# Altium schematic sheet STB_SensorBoard.SchDoc
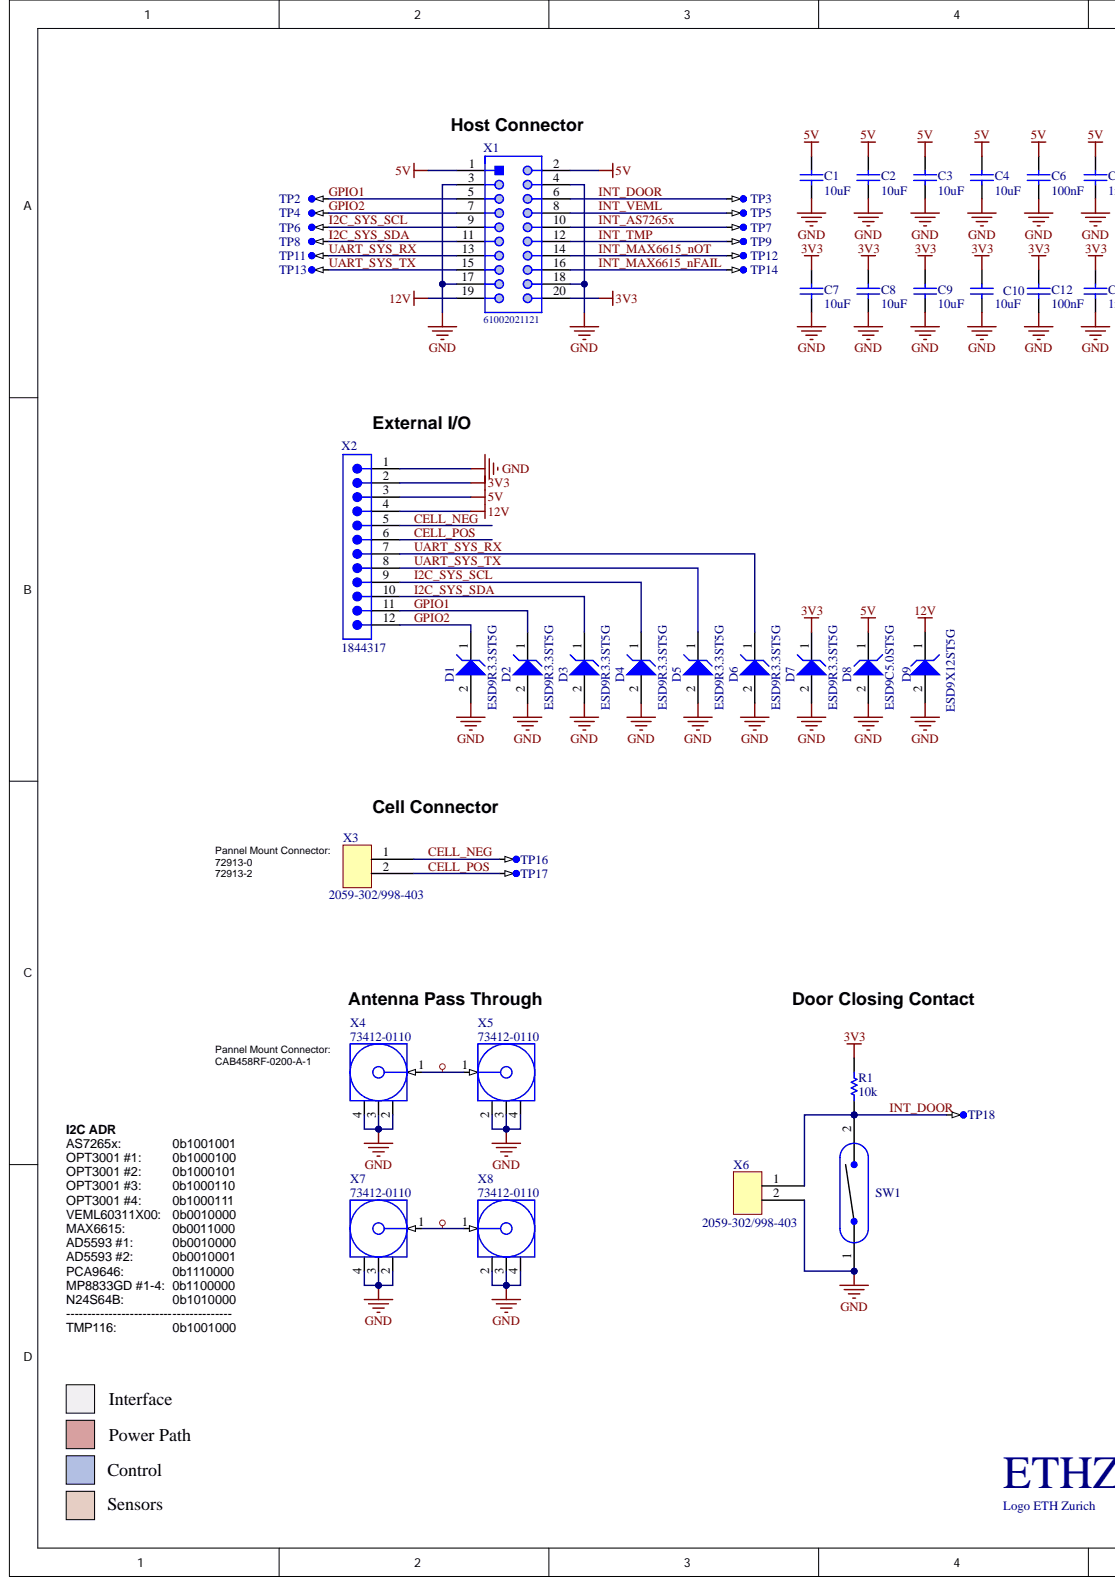
[diagram p0: STB_SensorBoard.SchDoc, left]
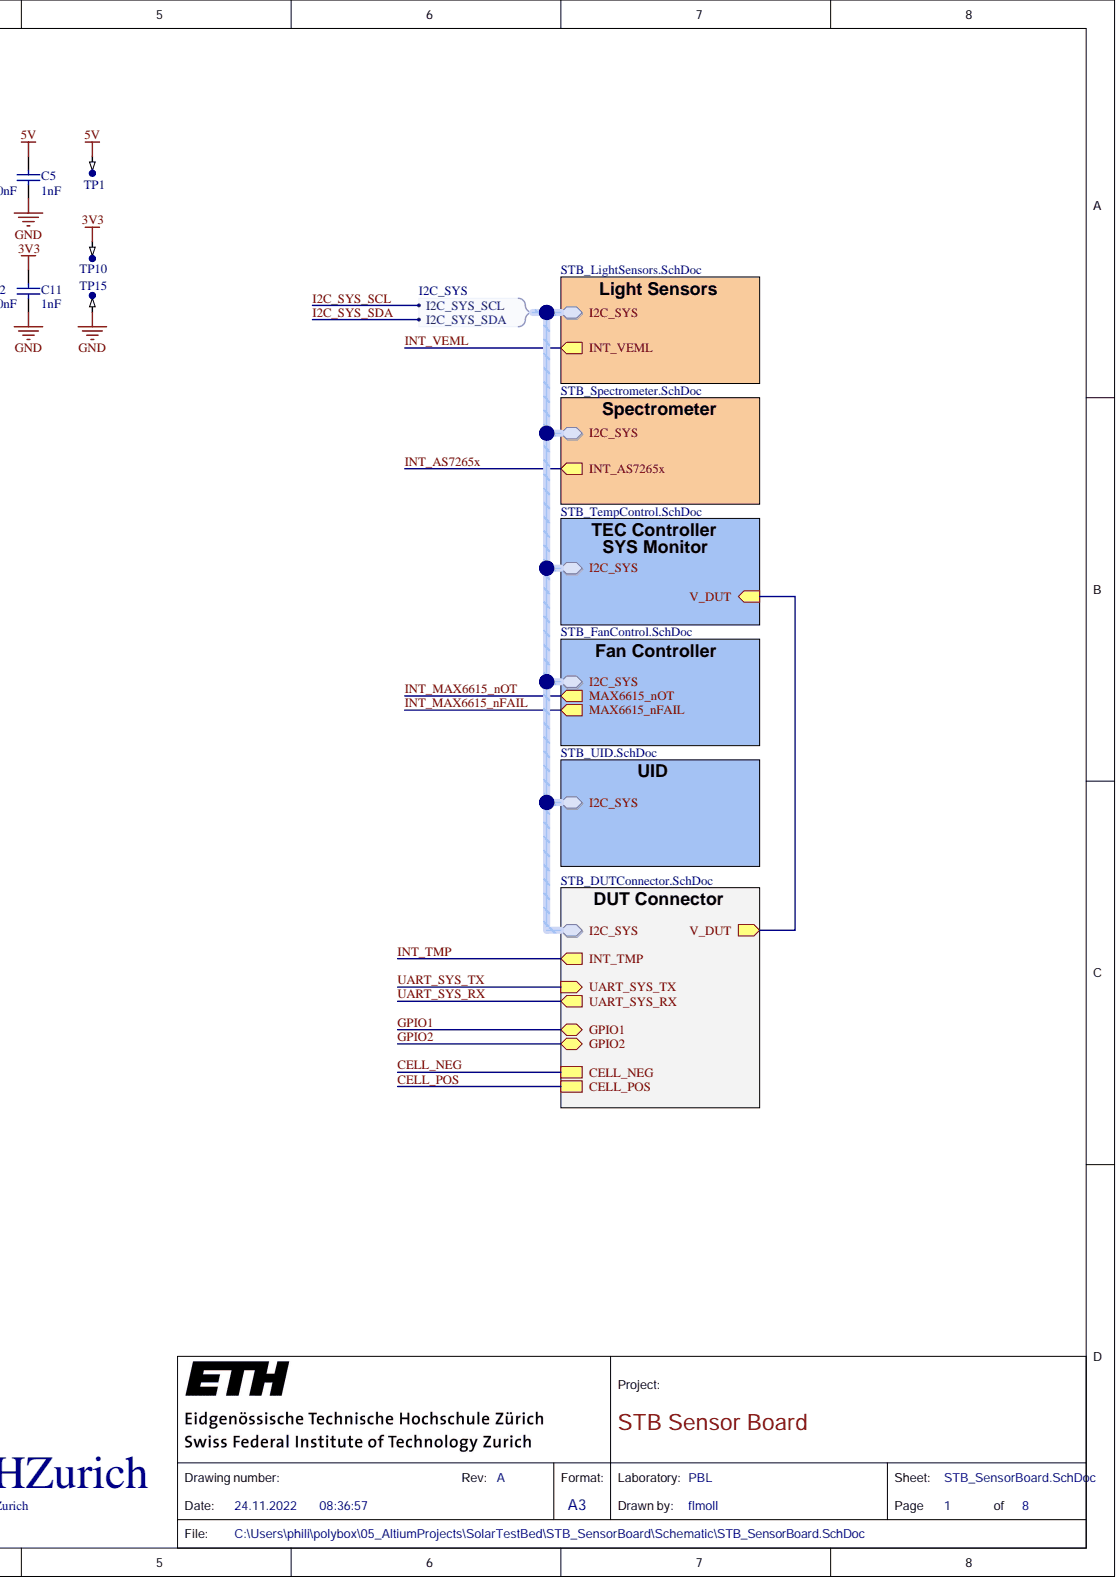
[diagram p1: STB_SensorBoard.SchDoc, right]

## Parts
A1  Logo ETH Zurich  [eth-zurich_5mm]  (no BOM)
C1  10uF  [CAPC0603(1608)100_L]
C2  10uF  [CAPC0603(1608)100_L]
C3  10uF  [CAPC0603(1608)100_L]
C4  10uF  [CAPC0603(1608)100_L]
C5  1nF  [CAPC1005X55X25ML5T10]
C6  100nF  [CAPC1050X55X23LL05T13]
C7  10uF  [CAPC0603(1608)100_L]
C8  10uF  [CAPC0603(1608)100_L]
C9  10uF  [CAPC0603(1608)100_L]
C10  10uF  [CAPC0603(1608)100_L]
C11  1nF  [CAPC1005X55X25ML5T10]
C12  100nF  [CAPC1050X55X23LL05T13]
D1  ESD9R3.3ST5G  [ONSC-SOD-923-2-514AB-01_V]
D2  ESD9R3.3ST5G  [ONSC-SOD-923-2-514AB-01_V]
D3  ESD9R3.3ST5G  [ONSC-SOD-923-2-514AB-01_V]
D4  ESD9R3.3ST5G  [ONSC-SOD-923-2-514AB-01_V]
D5  ESD9R3.3ST5G  [ONSC-SOD-923-2-514AB-01_V]
D6  ESD9R3.3ST5G  [ONSC-SOD-923-2-514AB-01_V]
D7  ESD9R3.3ST5G  [ONSC-SOD-923-2-514AB-01_V]
D8  ESD9C5.0ST5G  Rep Pk Reverse Voltage-Max: 5V  [ONSC-SOD-923-2-514AB-01_V]
D9  ESD9X12ST5G  Rep Pk Reverse Voltage-Max: 12V  [ONSC-SOD-923-2-514AA-01_V]
R1  10k  [RESC1005X40X25LL05T05]
SW1  MK17-B-2  [SMEL-MK17-B-2_V]  (value not drawn)
TP1  testPoint_1mm  [TP-SM-C-100]  (value not drawn)  (no BOM)
TP2  testPoint_1mm  [TP-SM-C-100]  (value not drawn)  (no BOM)
TP3  testPoint_1mm  [TP-SM-C-100]  (value not drawn)  (no BOM)
TP4  testPoint_1mm  [TP-SM-C-100]  (value not drawn)  (no BOM)
TP5  testPoint_1mm  [TP-SM-C-100]  (value not drawn)  (no BOM)
TP6  testPoint_1mm  [TP-SM-C-100]  (value not drawn)  (no BOM)
TP7  testPoint_1mm  [TP-SM-C-100]  (value not drawn)  (no BOM)
TP8  testPoint_1mm  [TP-SM-C-100]  (value not drawn)  (no BOM)
TP9  testPoint_1mm  [TP-SM-C-100]  (value not drawn)  (no BOM)
TP10  testPoint_1mm  [TP-SM-C-100]  (value not drawn)  (no BOM)
TP11  testPoint_1mm  [TP-SM-C-100]  (value not drawn)  (no BOM)
TP12  testPoint_1mm  [TP-SM-C-100]  (value not drawn)  (no BOM)
TP13  testPoint_1mm  [TP-SM-C-100]  (value not drawn)  (no BOM)
TP14  testPoint_1mm  [TP-SM-C-100]  (value not drawn)  (no BOM)
TP15  testPoint_1mm  [TP-SM-C-100]  (value not drawn)  (no BOM)
TP16  testPoint_1mm  [TP-SM-C-100]  (value not drawn)  (no BOM)
TP17  testPoint_1mm  [TP-SM-C-100]  (value not drawn)  (no BOM)
TP18  testPoint_1mm  [TP-SM-C-100]  (value not drawn)  (no BOM)
X1  61002021121  [61002021121]
X2  1844317  [1844317]
X3  2059-302/998-403  [2059-302/998-403]
X4  73412-0110  [MOLX-73412-0110_V]
X5  73412-0110  [MOLX-73412-0110_V]
X6  2059-302/998-403  [2059-302/998-403]
X7  73412-0110  [MOLX-73412-0110_V]
X8  73412-0110  [MOLX-73412-0110_V]

## Nets
3V3: C7.2 C8.2 C9.2 C10.2 C11.2 C12.2 D7.1 R1.2 TP10.TP X1.20 X2.2
5V: C1.2 C2.2 C3.2 C4.2 C5.2 C6.2 D8.1 TP1.TP X1.1 X1.2 X2.3
12V: D9.1 X1.19 X2.4
GND: C1.1 C2.1 C3.1 C4.1 C5.1 C6.1 C7.1 C8.1 C9.1 C10.1 C11.1 C12.1 D1.2 D2.2 D3.2 D4.2 D5.2 D6.2 D7.2 D8.2 D9.2 SW1.1 TP15.TP X1.3 X1.4 X1.17 X1.18 X2.1 X4.2 X4.3 X4.4 X5.2 X5.3 X5.4 X6.2 X7.2 X7.3 X7.4 X8.2 X8.3 X8.4
CELL_NEG: TP16.TP X2.5 X3.1
CELL_POS: TP17.TP X2.6 X3.2
GPIO1: D2.1 TP2.TP X1.5 X2.11
GPIO2: D1.1 TP4.TP X1.7 X2.12
I2C_SYS_SCL: D4.1 TP6.TP X1.9 X2.9
I2C_SYS_SDA: D3.1 TP8.TP X1.11 X2.10
INT_AS7265x: TP7.TP X1.10
INT_DOOR: R1.1 SW1.2 TP3.TP TP18.TP X1.6 X6.1
INT_MAX6615_nFAIL: TP14.TP X1.16
INT_MAX6615_nOT: TP12.TP X1.14
INT_TMP: TP9.TP X1.12
INT_VEML: TP5.TP X1.8
UART_SYS_RX: D6.1 TP11.TP X1.13 X2.7
UART_SYS_TX: D5.1 TP13.TP X1.15 X2.8
NetX4_1: X4.1 X5.1
NetX7_1: X7.1 X8.1
V_DUT:

## Library data of the parts
A1 = CMP-00038-1: Library Name: ETHZ Vault
C1-C4 C7-C10 = CMP-1035-02799-1: CAP 10uF 10V ±10% 0603 (1608 Metric) Thickness 1mm SMD; Manufacturer: Samsung; Manufacturer Part Number: CL10A106MP8NNNC; Case-EIA: 0603; Case-Metric: 1608; Dielectric: X5R; Tolerance: Â±10%; Capacitance: 10uF; Case Code (Imperial): 0603; Case Code (Metric): 1608; Case/Package: 0603; Category: Ceramic Capacitors; Component Kind: Standard; ComponentLink1Description: https://datasheet.ciiva.com/25793/cl10a106mp8nnnc-25793222.pdf?src-supplier=Digi-Key; ComponentLink1URL: https://datasheet.ciiva.com/25793/cl10a106mp8nnnc-25793222.pdf?src-supplier=Digi-Key; ComponentLink2Description: https://datasheet.ciiva.com/16200/cl10a106mp8nnnc-16200411.pdf?src-supplier=Future+Electronics; ComponentLink2URL: https://datasheet.ciiva.com/16200/cl10a106mp8nnnc-16200411.pdf?src-supplier=Future+Electronics; ComponentLink3Description: https://datasheet.ciiva.com/26946/getdatasheetpartid-357531-26946980.pdf?src-supplier=Verical; ComponentLink3URL: https://datasheet.ciiva.com/26946/getdatasheetpartid-357531-26946980.pdf?src-supplier=Verical; Contact Plating: Tin; Depth: 0.8mm; Height: 0.8mm; Lead Free: Lead Free; Length: 1.6mm; Library Name: Altium Content Vault; Max Operating Temperature: 85°C; Max Thickness: 1 mm; Min Operating Temperature: -55°C; Mount: Surface Mount; Package Quantity: 1; Packaging: Tape and Reel; Pricing 1: 1=0.26000, 10=0.17600, 50=0.10580, 100=0.08930, 500=0.06112, 1000=0.05407 (USD); Radiation Hardening: No; Rated Voltage: 10 V; RoHS: Yes; Stock 1: 643992; Supplier 1: Digi-Key; Supplier Part Number 1: 1276-1871-1-ND; Technology: SMT; Thickness: 0.035inch; Value: 10uF; Voltage Rating: 10V; Voltage Rating (DC): 10V; Width: 0.031inch
C5 C11 = CMP-1662-00017-1: Chip Capacitor, 1 nF, +/- 10%, 50 V, -55 to 125 degC, 0402 (1005 Metric), RoHS, Tape and Reel; Manufacturer: Murata; Manufacturer Part Number: GRM155R71H102KA01D; Capacitor Type: Ceramic; Case\/Package: 0402; Multilayer: Yes; Rated DC Voltage (URdc): 50V; Temperature Characteristics Code: X7R; Voltage Rating: 50 V; Case Code (Imperial): 0402; Case Code (Metric): 1005; Category: Ceramic Capacitors; Component Kind: Standard; ComponentLink1Description: Manufacturer URL; ComponentLink1URL: http://www.murata.com/; ComponentLink2Description: Datasheet; ComponentLink2URL: http://psearch.en.murata.com/capacitor/product/GRM155R71H102KA01%23.pdf; ComponentLink3Description: https://datasheet.ciiva.com:443/pdfs/VipMasterIC/IC/MURE/MURE-S-A0008911154/MURE-S-A0008911121-1.pdf?src-supplier=IHS+Markit; ComponentLink3URL: https://datasheet.ciiva.com:443/pdfs/VipMasterIC/IC/MURE/MURE-S-A0008911154/MURE-S-A0008911121-1.pdf?src-supplier=IHS+Markit; ComponentLink4Description: https://datasheet.ciiva.com/6072/1784401-6072451.pdf?src-supplier=Farnell; ComponentLink4URL: https://datasheet.ciiva.com/6072/1784401-6072451.pdf?src-supplier=Farnell; ComponentLink5Description: https://datasheet.ciiva.com/129/partnumbering-e-01-129755.pdf?src-supplier=Digi-Key; ComponentLink5URL: https://datasheet.ciiva.com/129/partnumbering-e-01-129755.pdf?src-supplier=Digi-Key; ComponentLink6Description: https://datasheet.ciiva.com/18101/getdatasheetpartid-37826-18101056.pdf?src-supplier=Verical; ComponentLink6URL: https://datasheet.ciiva.com/18101/getdatasheetpartid-37826-18101056.pdf?src-supplier=Verical; ComponentLink7Description: https://datasheet.ciiva.com/29199/454211-da-01-en-vs-kondensator-0402-29199736.pdf?src-supplier=Conrad; ComponentLink7URL: https://datasheet.ciiva.com/29199/454211-da-01-en-vs-kondensator-0402-29199736.pdf?src-supplier=Conrad; ComponentLink8Description: https://datasheet.ciiva.com/14116/grmseries-highdielectrictype-eng-14116845.pdf?src-supplier=Distrelec; ComponentLink8URL: https://datasheet.ciiva.com/14116/grmseries-highdielectrictype-eng-14116845.pdf?src-supplier=Distrelec; ComponentLink9Description: https://datasheet.ciiva.com/14116/grmseries-highdielectrictype-eng-14116906.pdf?src-supplier=Distrelec; ComponentLink9URL: https://datasheet.ciiva.com/14116/grmseries-highdielectrictype-eng-14116906.pdf?src-supplier=Distrelec; ComponentLink10Description: https://datasheet.ciiva.com/28659/608985-28659452.pdf?src-supplier=Element14; ComponentLink10URL: https://datasheet.ciiva.com/28659/608985-28659452.pdf?src-supplier=Element14; ComponentLink11Description: https://datasheet.ciiva.com/129/partnumbering-e-01-129754.pdf?src-supplier=Digi-Key; ComponentLink11URL: https://datasheet.ciiva.com/129/partnumbering-e-01-129754.pdf?src-supplier=Digi-Key; ComponentLink12Description: https://datasheet.ciiva.com/129/partnumbering-e-01-129753.pdf?src-supplier=Digi-Key; ComponentLink12URL: https://datasheet.ciiva.com/129/partnumbering-e-01-129753.pdf?src-supplier=Digi-Key; ComponentLink13Description: https://datasheet.ciiva.com/24217/c02e-24217773.pdf?src-supplier=Mouser; ComponentLink13URL: https://datasheet.ciiva.com/24217/c02e-24217773.pdf?src-supplier=Mouser; DatasheetVersion: 07/2016; Dielectric Material: Ceramic; Height: 0.5 mm; JESD-609 Code: e3; Length: 1 mm; Library Name: Altium Content Vault; Max Operating Temperature: 125 degC; Min Operating Temperature: -55 degC; Mount: Surface Mount; Mounting Technology: Surface Mount; Negative Tolerance: 10%; Number of Pins: 2; Package Quantity: 10000; Package Shape: Rectangular; Package Style: SMTMeter; PackageDescription: 2-Pin Surface Mount Device, Body 1 x 0.5 mm; PackageReference: 0402; Packaging: Tape and Reel; Pins: 2; Positive Tolerance: 10%; Pricing 1: 1=0.10000, 10=0.01800, 50=0.01000, 100=0.00810, 500=0.00578, 1000=0.00454, 2500=0.00412, 5000=0.00380 (USD); Radiation Hardening: No; REACH SVHC: No SVHC; Reach SVHC Compliant: Yes; RoHS: Yes; RoHS Compliant: Yes; RoHSCompliant: true; Size Code: 0402; Stock 1: 8959614; Supplier 1: Digi-Key; Supplier Part Number 1: 490-1303-6-ND; Terminal Finish: Nickel; Termination: Wraparound; Tolerance: 10%; Type (Capacitors): X7R; Value: 1 nF; Voltage Rating (DC): 50 V; Width: 0.5 mm
C6 C12 = CMP-2000-05439-1: Chip Capacitor, 100 nF, +/- 10%, 16 V, -55 to 125 degC, 0402 (1005 Metric), RoHS, Tape and Reel; Manufacturer: Samsung Electro-Mechanics; Manufacturer Part Number: CL05B104KO5NNNC; Capacitor Type: Ceramic; Dielectric: X7R; Multilayer: Yes; Rated DC Voltage (URdc): 16V; Case Size/Dimension: 0402; Category: Ceramic Capacitors; Component Kind: Standard; ComponentLink1Description: https://datasheet.ciiva.com:443/pdfs/VipMasterIC/IC/SEMS/SEMS-S-A0008384515/SEMS-S-A0008872921-1.pdf?src-supplier=IHS+Markit; ComponentLink1URL: https://datasheet.ciiva.com:443/pdfs/VipMasterIC/IC/SEMS/SEMS-S-A0008384515/SEMS-S-A0008872921-1.pdf?src-supplier=IHS+Markit; ComponentLink2Description: https://datasheet.ciiva.com/26920/getdatasheetpartid-359683-26920169.pdf?src-supplier=Verical; ComponentLink2URL: https://datasheet.ciiva.com/26920/getdatasheetpartid-359683-26920169.pdf?src-supplier=Verical; ComponentLink3Description: https://datasheet.ciiva.com/29198/458718-da-01-en-vs-kondensator-0402-29198423.pdf?src-supplier=Conrad; ComponentLink3URL: https://datasheet.ciiva.com/29198/458718-da-01-en-vs-kondensator-0402-29198423.pdf?src-supplier=Conrad; ComponentLink4Description: https://datasheet.ciiva.com/26309/mlcc-catalogdownload2013-eng-26309003.pdf?src-supplier=Distrelec; ComponentLink4URL: https://datasheet.ciiva.com/26309/mlcc-catalogdownload2013-eng-26309003.pdf?src-supplier=Distrelec; ComponentLink5Description: https://datasheet.ciiva.com/16208/cl05b104kq5nnnc-16208343.pdf?src-supplier=Future+Electronics; ComponentLink5URL: https://datasheet.ciiva.com/16208/cl05b104kq5nnnc-16208343.pdf?src-supplier=Future+Electronics; Datasheet URL: https://datasheet.ciiva.com/29198/458718-da-01-en-vs-kondensator-0402-29198423.pdf; Datasheet Version: Nov-10; Dielectric Material: Ceramic; Height: 0.55mm; JESD-609 Code: e3; Length: 1mm; Library Name: Altium Content Vault; Manufacturer URL: http://www.samsungsem.com/global/index.jsp; Max Operating Temperature: 125°C; Min Operating Temperature: -55°C; Mount: Surface Mount; Negative Tolerance: 10%; Number of Pins: 2; Package Shape: Rectangular; Package Style: SMTMeter; Packaging: Tape and Reel; Positive Tolerance: 10%; Pricing 1: 1=0.10000, 10=0.02400, 50=0.01300, 100=0.01050, 500=0.00752, 1000=0.00592, 2500=0.00538, 5000=0.00495 (USD); Rated Voltage: 16V; REACH SVHC: Yes; RoHS: Yes; RoHS Compliant: Yes; Size Code: 0402; Stock 1: 24854634; Supplier 1: Digi-Key; Supplier Part Number 1: 1276-1001-6-ND; Temperature Characteristics Code: X7R; Terminal Finish: Nickel; Termination: Wraparound; Tolerance (%): ±10%; Value: 100nF; Width: 0.5mm
D1-D7 = CMP-1063-00117-1: Transient Voltage Suppressor, ESD Protection Diode with Ultra-Low Leakage, 2-Pin SOD-923, Pb-Free, Tape and Reel; Manufacturer: On Semiconductor; Manufacturer Part Number: SZESD9R3.3ST5G; Breakdown Voltage-Nom: 4.8V; C Max (pF): 0.9; Clamping Voltage-Max: 7.8V; Diode Type: TRANS VOLTAGE SUPPRESSOR; Direction: Unidirectional; IR Max (uA): 0.001; Number of Lines: 1; Rep Pk Reverse Voltage-Max: 3.3V; V(BR) Min (V): 4.8; VRWM Max (V): 3.3; Category: ESD and Circuit Protection ICs; Component Kind: Standard; ComponentLink1Description: Manufacturer URL; ComponentLink1URL: http://www.onsemi.com/; ComponentLink2Description: Datasheet; ComponentLink2URL: http://www.onsemi.com/pub_link/Collateral/ESD9R3.3S-D.PDF; ComponentLink3Description: Package Specification; ComponentLink3URL: http://www.onsemi.com/pub_link/Collateral/514AB.PDF; ComponentLink4Description: https://datasheet.ciiva.com:443/pdfs/VipMasterIC/IC/ONSM/ONSM-S-A0003514262/ONSM-S-A0003583458-1.pdf?src-supplier=IHS+Markit; ComponentLink4URL: https://datasheet.ciiva.com:443/pdfs/VipMasterIC/IC/ONSM/ONSM-S-A0003514262/ONSM-S-A0003583458-1.pdf?src-supplier=IHS+Markit; ComponentLink5Description: https://datasheet.ciiva.com/24087/esd9r3-3s-d-241663-24087547.pdf?src-supplier=Mouser; ComponentLink5URL: https://datasheet.ciiva.com/24087/esd9r3-3s-d-241663-24087547.pdf?src-supplier=Mouser; DatasheetVersion: Rev. 1; JESD-609 Code: e3; Library Name: Altium Content Vault; Moisture Sensitivity Level: 1; Mounting Technology: Surface Mount; PackageDescription: 2-Pin SOD, Body 0.8 x 0.6 mm; PackageReference: SOD-923-2-514AB-01; PackageVersion: Rev. C, 03/2011; Packing: Tape and Reel; PartNumber: ESD9R3.3ST5G; Polarity: Unidirectional; Pricing 1: 1=0.61000, 10=0.52500, 100=0.39180, 500=0.30782, 1000=0.23786, 2000=0.21688 (USD); REACH SVHC: Yes; RoHS: Pb-Free; RoHS Compliant: Yes; Stock 1: 31698; Supplier 1: Digi-Key; Supplier Part Number 1: SZESD9R3.3ST5GOSDKR-ND; Surface Mount: Yes; Terminal Finish: Tin
D8 = CMP-1063-00110-1: Transient Voltage Suppressor, Micro-Packaged Diode for ESD Protection, 2-Pin SOD-923, Pb-Free, Tape and Reel; Manufacturer: On Semiconductor; C Max (pF): 6.2; Diode Element Material: SILICON; Diode Type: TRANS VOLTAGE SUPPRESSOR; Direction: Unidirectional; IR Max (uA): 0.5; Max Power Dissipation: 150mW; Min Breakdown Voltage: 11V; Number of Elements: 1; Package Body Material: Plastic; Qualification Status: Not Qualified; Reference Standard: AEC-Q101; Time @ Peak Reflow Temperature-Max (s): 40s; V(BR) Min (V): 11; VRWM Max (V): 5; Category: ESD and Circuit Protection ICs; Component Kind: Standard; ComponentLink1Description: Manufacturer URL; ComponentLink1URL: http://www.onsemi.com/; ComponentLink2Description: Datasheet; ComponentLink2URL: http://www.onsemi.cn/pub_link/Collateral/ESD9C3.3S-D.PDF; ComponentLink3Description: Package Specification; ComponentLink3URL: http://www.onsemi.com/pub_link/Collateral/514AB.PDF; ComponentLink4Description: https://datasheet.ciiva.com:443/pdfs/VipMasterIC/IC/ONSM/ONSM-S-A0003514262/ONSM-S-A0003579756-1.pdf?src-supplier=IHS+Markit; ComponentLink4URL: https://datasheet.ciiva.com:443/pdfs/VipMasterIC/IC/ONSM/ONSM-S-A0003514262/ONSM-S-A0003579756-1.pdf?src-supplier=IHS+Markit; ComponentLink5Description: https://datasheet.ciiva.com/1219/1748974-1219321.pdf?src-supplier=Newark; ComponentLink5URL: https://datasheet.ciiva.com/1219/1748974-1219321.pdf?src-supplier=Newark; ComponentLink6Description: https://datasheet.ciiva.com/4509/esd9c3-3s-d-241629-4509822.pdf?src-supplier=Mouser; ComponentLink6URL: https://datasheet.ciiva.com/4509/esd9c3-3s-d-241629-4509822.pdf?src-supplier=Mouser; ComponentLink7Description: https://datasheet.ciiva.com/15143/getdatasheetpartid-53763-15143125.pdf?src-supplier=Verical; ComponentLink7URL: https://datasheet.ciiva.com/15143/getdatasheetpartid-53763-15143125.pdf?src-supplier=Verical; ComponentLink8Description: https://datasheet.ciiva.com/339/esd9c3-3s-d-339778.pdf?src-supplier=Digi-Key; ComponentLink8URL: https://datasheet.ciiva.com/339/esd9c3-3s-d-339778.pdf?src-supplier=Digi-Key; ComponentLink9Description: https://datasheet.ciiva.com/11195/esd9c3-3s-d-11195341.pdf?src-supplier=Avnet; ComponentLink9URL: https://datasheet.ciiva.com/11195/esd9c3-3s-d-11195341.pdf?src-supplier=Avnet; ComponentLink10Description: https://datasheet.ciiva.com/339/esd9c3-3s-d-339779.pdf?src-supplier=Digi-Key; ComponentLink10URL: https://datasheet.ciiva.com/339/esd9c3-3s-d-339779.pdf?src-supplier=Digi-Key; ComponentLink11Description: https://datasheet.ciiva.com/339/esd9c3-3s-d-339780.pdf?src-supplier=Digi-Key; ComponentLink11URL: https://datasheet.ciiva.com/339/esd9c3-3s-d-339780.pdf?src-supplier=Digi-Key; DatasheetVersion: Rev. 3; JESD-30 Code: R-PDSO-F2; JESD-609 Code: e3; Library Name: Altium Content Vault; Manufacturer Part Number: ESD9C5.0ST5G; Max Operating Temperature: 150°C; Min Operating Temperature: -55°C; Moisture Sensitivity Level: 1; Mounting Technology: Surface Mount; Number of Lines: 1; Number of Pins: 2; Package Shape: Rectangular; Package Style: SMALL OUTLINEMeter; PackageDescription: 2-Pin SOD, Body 0.8 x 0.6 mm; PackageReference: SOD-923-2-514AB-01; PackageVersion: Rev. C, 03/2011; Packing: Tape and Reel; PartNumber: ESD9C5.0ST5G; Peak Reflow Temperature (Cel): 260°C; Polarity: Unidirectional; Pricing 1: 1=0.38000, 10=0.30900, 100=0.16370, 500=0.10772, 1000=0.07325, 2000=0.06607 (USD); REACH SVHC: Yes; RoHS: Pb-Free; RoHS Compliant: Yes; Stock 1: 345553; Supplier 1: Digi-Key; Supplier Part Number 1: ESD9C5.0ST5GOSDKR-ND; Surface Mount: Yes; Technology: AVALANCHE; Terminal Finish: Tin; Terminal Form: Flat; Terminal Position: DUAL
D9 = CMP-1063-00105-1: Transient Voltage Suppressor, Micro-Packaged Diode for ESD Protection, 2-Pin SOD-923, Pb-Free, Tape and Reel; Manufacturer: On Semiconductor; Clamping Voltage-Max: 23.7V; Diode Element Material: SILICON; Diode Type: TRANS VOLTAGE SUPPRESSOR; Direction: Unidirectional; IR Max (uA): 1; Max Power Dissipation: 150mW; Min Breakdown Voltage: 13.5V; Non-rep Peak Rev Power Dis-Max: 140W; Package Body Material: Plastic; PPK Max (W): 140; Qualification Status: Not Qualified; Time @ Peak Reflow Temperature-Max (s): 40s; V(BR) Min (V): 13.5; VRWM Max (V): 12; Category: ESD and Circuit Protection ICs; Component Kind: Standard; ComponentLink1Description: Manufacturer URL; ComponentLink1URL: http://www.onsemi.com/; ComponentLink2Description: Datasheet; ComponentLink2URL: http://www.onsemi.com/pub_link/Collateral/ESD9X3.3ST5G-D.PDF; ComponentLink3Description: Package Specification; ComponentLink3URL: http://www.onsemi.com/pub_link/Collateral/514AA.PDF; ComponentLink4Description: https://datasheet.ciiva.com:443/pdfs/VipMasterIC/IC/ONSM/ONSM-S-A0003579749/ONSM-S-A0003579749-1.pdf?src-supplier=IHS+Markit; ComponentLink4URL: https://datasheet.ciiva.com:443/pdfs/VipMasterIC/IC/ONSM/ONSM-S-A0003579749/ONSM-S-A0003579749-1.pdf?src-supplier=IHS+Markit; ComponentLink5Description: https://datasheet.ciiva.com/6577/esd9x3-3st5g-d-6577990.pdf?src-supplier=Digi-Key; ComponentLink5URL: https://datasheet.ciiva.com/6577/esd9x3-3st5g-d-6577990.pdf?src-supplier=Digi-Key; ComponentLink6Description: https://datasheet.ciiva.com/16393/esd9x3-3st5g-d-16393983.pdf?src-supplier=Future+Electronics; ComponentLink6URL: https://datasheet.ciiva.com/16393/esd9x3-3st5g-d-16393983.pdf?src-supplier=Future+Electronics; ComponentLink7Description: https://datasheet.ciiva.com/353/esd9x3-3st5g-d-353784.pdf?src-supplier=Digi-Key; ComponentLink7URL: https://datasheet.ciiva.com/353/esd9x3-3st5g-d-353784.pdf?src-supplier=Digi-Key; ComponentLink8Description: https://datasheet.ciiva.com/353/esd9x3-3st5g-d-353785.pdf?src-supplier=Digi-Key; ComponentLink8URL: https://datasheet.ciiva.com/353/esd9x3-3st5g-d-353785.pdf?src-supplier=Digi-Key; DatasheetVersion: Rev. 7; JESD-30 Code: R-PDSO-F2; JESD-609 Code: e3; Library Name: Altium Content Vault; Manufacturer Part Number: ESD9X12ST5G; Max Operating Temperature: 150°C; Min Operating Temperature: -55°C; Moisture Sensitivity Level: 1; Mounting Technology: Surface Mount; Number of Elements: 1; Number of Lines: 1; Number of Pins: 2; Package Shape: Rectangular; Package Style: SMALL OUTLINEMeter; PackageDescription: 2-Pin SOD, Body 0.8 x 0.6 mm; PackageReference: SOD-923-2-514AA-01; PackageVersion: Rev. E, 03/2011; Packing: Tape and Reel; PartNumber: ESD9X12ST5G; Peak Reflow Temperature (Cel): 260°C; Polarity: Unidirectional; Pricing 2: 1=0.30000, 10=0.24400, 100=0.12930, 500=0.08506, 1000=0.05784, 2000=0.05218 (USD); REACH SVHC: Yes; RoHS: Pb-Free; RoHS Compliant: Yes; Stock 2: 71759; Supplier 1: Digi-Key; Supplier 2: Digi-Key; Supplier Part Number 2: ESD9X12ST5GOSDKR-ND; Surface Mount: Yes; Technology: AVALANCHE; Terminal Finish: Tin; Terminal Form: Flat; Terminal Position: DUAL
R1 = CMP-2000-07588-1: Chip Resistor, 10 KOhm, +/- 1%, 0.1 W, -55 to 155 degC, 0402 (1005 Metric), RoHS, Tape and Reel; Manufacturer: Panasonic; Manufacturer Part Number: ERJ-2RKF1002X; Case\/Package: 0402; Max Power Dissipation: 100mW; Package Height: 0.35mm; Package Length: 1mm; Package Width: 0.5mm; Rated Temperature: 70°C; Reference Standard: AEC-Q200; Temperature Coefficient: 100ppm\/degreesC; Value: 10 kOhm; Voltage Rating: 50V; Case Code (Imperial): 0402; Case Code (Metric): 1005; Category: Chip SMD Resistors; Component Kind: Standard; ComponentLink1Description: https://datasheet.ciiva.com:443/pdfs/VipMasterIC/IC/PANA/PANA-S-A0009889626/PANA-S-A0009889626-1.pdf?src-supplier=IHS+Markit; ComponentLink1URL: https://datasheet.ciiva.com:443/pdfs/VipMasterIC/IC/PANA/PANA-S-A0009889626/PANA-S-A0009889626-1.pdf?src-supplier=IHS+Markit; ComponentLink2Description: https://datasheet.ciiva.com/11821/0900766b80f80784-11821171.pdf?src-supplier=RSComponents; ComponentLink2URL: https://datasheet.ciiva.com/11821/0900766b80f80784-11821171.pdf?src-supplier=RSComponents; ComponentLink3Description: https://datasheet.ciiva.com/27055/1987020-27055643.pdf?src-supplier=Jameco; ComponentLink3URL: https://datasheet.ciiva.com/27055/1987020-27055643.pdf?src-supplier=Jameco; ComponentLink4Description: https://datasheet.ciiva.com/43467/1678782-43467402.pdf?src-supplier=Farnell; ComponentLink4URL: https://datasheet.ciiva.com/43467/1678782-43467402.pdf?src-supplier=Farnell; ComponentLink5Description: https://datasheet.ciiva.com/28514/1678782-28514185.pdf?src-supplier=Element14; ComponentLink5URL: https://datasheet.ciiva.com/28514/1678782-28514185.pdf?src-supplier=Element14; ComponentLink6Description: https://datasheet.ciiva.com/24023/aoa0000ce2-24023017.pdf?src-supplier=Mouser; ComponentLink6URL: https://datasheet.ciiva.com/24023/aoa0000ce2-24023017.pdf?src-supplier=Mouser; ComponentLink7Description: https://datasheet.ciiva.com/16782/70260748-16782590.pdf?src-supplier=Allied+Electronics; ComponentLink7URL: https://datasheet.ciiva.com/16782/70260748-16782590.pdf?src-supplier=Allied+Electronics; ComponentLink8Description: https://datasheet.ciiva.com/16786/70260748-16786734.pdf?src-supplier=Allied+Electronics; ComponentLink8URL: https://datasheet.ciiva.com/16786/70260748-16786734.pdf?src-supplier=Allied+Electronics; ComponentLink9Description: https://datasheet.ciiva.com/9194/aoa0000ce2-9194142.pdf?src-supplier=Avnet; ComponentLink9URL: https://datasheet.ciiva.com/9194/aoa0000ce2-9194142.pdf?src-supplier=Avnet; ComponentLink10Description: https://datasheet.ciiva.com/16199/aoa0000ce2-16199478.pdf?src-supplier=Future+Electronics; ComponentLink10URL: https://datasheet.ciiva.com/16199/aoa0000ce2-16199478.pdf?src-supplier=Future+Electronics; ComponentLink11Description: https://datasheet.ciiva.com/48531/aoa0000ce2-48531069.pdf?src-supplier=Avnet; ComponentLink11URL: https://datasheet.ciiva.com/48531/aoa0000ce2-48531069.pdf?src-supplier=Avnet; ComponentLink12Description: https://datasheet.ciiva.com/30201/aoa0000ce2-30201022.pdf?src-supplier=Onlinecomponents.com; ComponentLink12URL: https://datasheet.ciiva.com/30201/aoa0000ce2-30201022.pdf?src-supplier=Onlinecomponents.com; Composition: ThickFilm; Construction: Rectangular; Contact Plating: Tin; Datasheet URL: https://industrial.panasonic.com/cdbs/www-data/pdf/RDA0000/AOA0000C304.pdf; Datasheet Version: 02/2017; Depth: 0.5mm; Height: 0.35mm; JESD-609 Code: e3; Lead Free: LeadFree; Length: 1mm; Library Name: Altium Content Vault; Manufacturer URL: http://www.panasonic.com/; Max Operating Temperature: 155degC; Military Standard: Not; Min Operating Temperature: -55degC; Mount: SurfaceMount; Mounting Technology: SurfaceMount; Number of Pins: 2; Number of Terminations: 2; Package Description: 2-Pin Surface Mount Device, Body 1 x 0.5 mm; Package Quantity: 1; Package Reference: 402; Package Shape: Rectangular; Package Style: SMTMeter; Packaging: TapeandReel; Power Rating: 100mW; Pricing 1: 1=0.10000, 10=0.03300, 100=0.01330, 1000=0.00599, 2500=0.00520, 5000=0.00429 (USD); Radiation Hardening: No; Rated Power Dissipation (P): 100mW; REACH SVHC: NoSVHC; Resistor Type: FIXED RESISTOR; RoHS: Yes; RoHS Compliant: Yes; RoHSCompliant: True; Size Code: 0402; Stock 1: 108; Supplier 1: Digi-Key; Supplier Part Number 1: P10.0KLDKR-ND; Technology: METAL GLAZE/THICK FILM; Terminal Finish: Nickel; Termination: SMD/SMT; Tolerance: 1%; Voltage Rating (DC): 50V; Width: 0.02inch; Working Voltage: 50V
SW1 = CMP-2000-06713-1: Molded Body Reed Switch, 100 V, 10 W, -40 to 130 degC, 2-Pin SMD, RoHS, Tape and Reel; Manufacturer: Standex Meder; Body Breadth: 2.1inch; Body Height: 2.1mm; Body Length or Diameter: 8.5mm; Package Shape/Style: Rectangular; Response Time: 100us; Sensors/Transducers Type: PROXIMITY SENSOR,PHOTOELECTRIC; Category: Signal Relays; Component Kind: Standard; ComponentLink1Description: https://datasheet.ciiva.com:443/pdfs/VipMasterIC/IC/STME/STME-S-A0010126788/STME-S-A0010126848-1.pdf?src-supplier=IHS+Markit; ComponentLink1URL: https://datasheet.ciiva.com:443/pdfs/VipMasterIC/IC/STME/STME-S-A0010126788/STME-S-A0010126848-1.pdf?src-supplier=IHS+Markit; ComponentLink2Description: https://datasheet.ciiva.com/2818/9171800022e-2818053.pdf?src-supplier=Digi-Key; ComponentLink2URL: https://datasheet.ciiva.com/2818/9171800022e-2818053.pdf?src-supplier=Digi-Key; ComponentLink3Description: https://datasheet.ciiva.com/4626/9171800022e-11078-4626565.pdf?src-supplier=Mouser; ComponentLink3URL: https://datasheet.ciiva.com/4626/9171800022e-11078-4626565.pdf?src-supplier=Mouser; ComponentLink4Description: https://datasheet.ciiva.com/1320/96345-1320425.pdf?src-supplier=Element14; ComponentLink4URL: https://datasheet.ciiva.com/1320/96345-1320425.pdf?src-supplier=Element14; ComponentLink5Description: https://datasheet.ciiva.com/15099/getdatasheetpartid-639745-15099041.pdf?src-supplier=Verical; ComponentLink5URL: https://datasheet.ciiva.com/15099/getdatasheetpartid-639745-15099041.pdf?src-supplier=Verical; ComponentLink6Description: https://datasheet.ciiva.com/30182/9171800022e-30182855.pdf?src-supplier=Onlinecomponents.com; ComponentLink6URL: https://datasheet.ciiva.com/30182/9171800022e-30182855.pdf?src-supplier=Onlinecomponents.com; ComponentLink7Description: https://datasheet.ciiva.com/15697/23-en-1-sensormk17e-15697662.pdf?src-supplier=Onlinecomponents.com; ComponentLink7URL: https://datasheet.ciiva.com/15697/23-en-1-sensormk17e-15697662.pdf?src-supplier=Onlinecomponents.com; Datasheet URL: http://www.masterelectronics.com/productFiles/mf-MDR/9171800022e.pdf; Datasheet Version: Rev. 17, 12/2014; Housing Material: Resin; Library Name: Altium Content Vault; Manufacturer Part Number: MK17-B-2; Manufacturer URL: https://standexelectronics.com; Max Operating Temperature: 130°C; Min Operating Temperature: -40°C; Mount: Surface Mount; Mounting Technology: SurfaceMount; Package Description: 2-Pin Surface Mount Device, Body 8.5 x 2.1 mm; Package Reference: MK17-B-2; Pricing 1: 2000=1.64933, 4000=1.49834, 6000=1.43750 (USD); REACH SVHC: No SVHC; RoHS: Yes; RoHS Compliant: Yes; Stock 1: 6000; Supplier 1: Digi-Key; Supplier Part Number 1: 374-1021-2-ND; Termination: Solder
TP1-TP18 = testPoint_1mm: testPoint 1mm; Library Name: STB_Schlib.SchLib
X1 = CMP-1502-01036-1: SMT Vertical Pin Header WR-PHD, Pitch 2.54 mm, Dual Row, 20 pins; Manufacturer: Wurth Elektronik; Manufacturer Series: 6100; Body Breadth: 0.2inch; Body Depth: 0.142inch; Body Length: 1inch; Body/Shell Style: RECEPTACLE; Connector Type: BOARD CONNECTOR; Contact Pattern: RECTANGULAR; Contact Resistance: 20mOhm; Contact Style: SQ PIN-SKT; Dielectric Withstanding Voltage (AC): 500V; DIN Conformance: No; Gender: Male; Insulation Resistance: 1GR; Mating Contact Pitch: 0.1inch; Mounting Option 1: LOCKING; Number Of Connectors: 1; Number of Contacts: 20; Number Of PCB Rows: 2; Option: General Purpose; PCB Contact Row Spacing: 5.4102mm; Pitch: 2.54mm; Rated Current: 3A; Reference Standard: UL; Reliability: COMMERCIAL; Withstanding Voltage: 500VAC; Working Voltage: 250VAC; Category: Connectors; Component Kind: Standard; ComponentLink1Description: https://datasheet.ciiva.com:443/pdfs/VipMasterIC/IC/MIDC/MIDCS04347/MIDCS04347-1.pdf?src-supplier=IHS+Markit; ComponentLink1URL: https://datasheet.ciiva.com:443/pdfs/VipMasterIC/IC/MIDC/MIDCS04347/MIDCS04347-1.pdf?src-supplier=IHS+Markit; ComponentLink2Description: https://katalog.we-online.de/em/datasheet/6100xx21121.pdf?src-supplier=Digi-Key; ComponentLink2URL: https://katalog.we-online.de/em/datasheet/6100xx21121.pdf?src-supplier=Digi-Key; ComponentLink3Description: http://www.farnell.com/cad/1737271.pdf?src-supplier=Element14; ComponentLink3URL: http://www.farnell.com/cad/1737271.pdf?src-supplier=Element14; Contact Finish - Mating: GOLD; Contact Finish - Termination: Gold (Au) - with Nickel (Ni) barrier; Contact Gender: Male; Contact Material: Copper Alloy; Datasheet URL: https://katalog.we-online.de/em/datasheet/6100xx21121.pdf; Filter Feature: No; Flammability Rating: UL94 V-0; IEC Conformance: No; Insulation Color: Black; Insulation Material: Polyamide; JESD-609 Code: e4; Library Name: Altium Content Vault; Manufacturer Part Number: 61002021121; Manufacturer URL: http://www.we-online.de; Mating Contact Row Spacing: 0.1inch; Max Operating Temperature: 125°C; MIL Conformance: No; Min Operating Temperature: -40°C; Mixed Contacts: No; Mount: Board; Mounting Style: STRAIGHT; Mounting Technology: SMT; Number of Rows Loaded: 2; Operating Temperature: -40to125degC; Orientation: Vertical; Package Reference: 61002021121; Packaging: Tube; Part Number: 61002021121; PCB Contact Pattern: RECTANGULAR; Pin Number: 20; Pricing 1: 1=3.72000, 10=3.15900, 24=2.63208, 48=2.19292, 96=1.99302, 192=1.81302 (USD); Rated Current (Signal): 3A; REACH SVHC: Yes; RoHS: Yes; RoHS Compliant: Yes; Row Number: Dual; Stock 1: 578; Supplier 1: Digi-Key; Supplier Part Number 1: 732-5468-5-ND; Terminal Pitch: 2.54mm; Termination: SMD/SMT; Publisher: WurthElektronik
X2 = CMP-00203-1: Manufacturer: Phoenix Contact; Current - IEC: 12A; Current - UL: 8A; Header Orientation: 90°, Right Angle; Number of Levels: 1; Number of Positions: 12; Voltage - IEC: 250V; Voltage - UL: 300V; Color: Green; Component Kind: Standard; ComponentLink1Description: 1844317.pdf; ComponentLink1URL: http://media.digikey.com/pdf/Data%20Sheets/Phoenix%20Contact%20PDFs/1844317.pdf; Contact Mating Finish: Tin; Features: -; Library Name: ETHZ Vault; Manufacturer Part Number: 1844317; Mounting Type: Through Hole; Operating Temperature: -; Packaging: Bulk; Part Status: Active; Plug Wire Entry: -; Positions Per Level: 12; Supplier: Digi-Key; Supplier Part Number: 277-5902-ND; Termination Style: Solder; Type: Header, Male Pins, Shrouded (4 Side); Wire Gauge or Range - AWG: -; Wire Gauge or Range - mm²: -
X3 X6 = 2059-302/998-403: Barrier Strip Terminal Block, 3A, 0.34mm2, 1 Row(s), 1 Deck(s); Manufacturer: WAGO; Fastening Method: PUSH-IN; Number of Decks: 1; Number of Ways: 2; Safety Approval: UL; Voltage Rating: 250V; Wire/Cable Gauge: 22AWG; Category: Connectors; Component Kind: Standard; ComponentLink1Description: https://datasheet.ciiva.com:443/pdfs/VipMasterIC/IC/WAGO/WAGO-S-A0007107760/WAGO-S-A0007107948-1.pdf?src-supplier=IHS+Markit; ComponentLink1URL: https://datasheet.ciiva.com:443/pdfs/VipMasterIC/IC/WAGO/WAGO-S-A0007107760/WAGO-S-A0007107948-1.pdf?src-supplier=IHS+Markit; ComponentLink2Description: https://datasheet.ciiva.com/41441/001208172-da-01-de-smd-leiterplattenklemme-2059-302-998-404-41441808.pdf?src-supplier=Conrad; ComponentLink2URL: https://datasheet.ciiva.com/41441/001208172-da-01-de-smd-leiterplattenklemme-2059-302-998-404-41441808.pdf?src-supplier=Conrad; ComponentLink3Description: https://datasheet.ciiva.com/46435/1860449-46435420.pdf?src-supplier=Element14; ComponentLink3URL: https://datasheet.ciiva.com/46435/1860449-46435420.pdf?src-supplier=Element14; Current Rating: 3A; Manufacturer Part Number: 2059-302/998-403; Mount: Board; Number of Rows: 1; Pricing 1: 1=1.25000, 10=0.87800, 25=0.81880, 50=0.74320, 100=0.73990, 250=0.62484, 500=0.59194 (USD); REACH SVHC: Yes; RoHS: Yes; RoHS Compliant: Yes; Stock 1: 17368; Supplier 1: Digi-Key; Supplier Part Number 1: 2946-2059-302/998-403CT-ND; Terminal and Terminal Block Type: BARRIER STRIP TERMINAL BLOCK; Wire Cross Section: 0.34mm2; Library Name: STB_Schlib.SchLib
X4 X5 X7 X8 = CMP-1676-00003-1: MCRF, PCB Vertical Jack Receptacle, 50 Ohm, 4-Pin SMD, RoHS, Tape and Reel; Manufacturer: Molex; Body Material: Polymer,Liquid Crystal Polymer; Body Plating: Gold; Connector Type: Coaxial; Frequency: 6 Hz; Gender: Female; Impedance: 50 Ohm; Insulation Resistance: 500MR; Mating Cycles: 30; Max Frequency: 6GHz; Polarity: Standard; Voltage Rating: 60 V; Category: RF / Coaxial Connectors; Component Kind: Standard; ComponentLink1Description: Manufacturer URL; ComponentLink1URL: http://www.molex.com/; ComponentLink2Description: Datasheet; ComponentLink2URL: http://www.molex.com/webdocs/datasheets/pdf/en-us/0734120110_RF_COAX_CONNECTORS.pdf; ComponentLink3Description: Package Specification; ComponentLink3URL: http://www.molex.com/pdm_docs/sd/734120110_sd.pdf; ComponentLink4Description: https://datasheet.ciiva.com/30219/250360734120110-rf-coax-nectors-30219809.pdf?src-supplier=Onlinecomponents.com; ComponentLink4URL: https://datasheet.ciiva.com/30219/250360734120110-rf-coax-nectors-30219809.pdf?src-supplier=Onlinecomponents.com; ComponentLink5Description: https://datasheet.ciiva.com/16653/0734120110-rf-coax-connectors-16653186.pdf?src-supplier=Avnet+Europe; ComponentLink5URL: https://datasheet.ciiva.com/16653/0734120110-rf-coax-connectors-16653186.pdf?src-supplier=Avnet+Europe; ComponentLink6Description: https://datasheet.ciiva.com/11762/0900766b80dfdeb4-11762356.pdf?src-supplier=RSComponents; ComponentLink6URL: https://datasheet.ciiva.com/11762/0900766b80dfdeb4-11762356.pdf?src-supplier=RSComponents; ComponentLink7Description: https://datasheet.ciiva.com/22773/1841262-22773140.pdf?src-supplier=Farnell; ComponentLink7URL: https://datasheet.ciiva.com/22773/1841262-22773140.pdf?src-supplier=Farnell; ComponentLink8Description: https://datasheet.ciiva.com/4600/0734120110-rf-coax-connectors-173239-4600647.pdf?src-supplier=Mouser; ComponentLink8URL: https://datasheet.ciiva.com/4600/0734120110-rf-coax-connectors-173239-4600647.pdf?src-supplier=Mouser; ComponentLink9Description: https://datasheet.ciiva.com/16081/1387750734120110-rf-coax-nectors-16081145.pdf?src-supplier=Onlinecomponents.com; ComponentLink9URL: https://datasheet.ciiva.com/16081/1387750734120110-rf-coax-nectors-16081145.pdf?src-supplier=Onlinecomponents.com; ComponentLink10Description: https://datasheet.ciiva.com/46384/1808577-46384459.pdf?src-supplier=Element14; ComponentLink10URL: https://datasheet.ciiva.com/46384/1808577-46384459.pdf?src-supplier=Element14; ComponentLink11Description: https://datasheet.ciiva.com/9419/0734120110-rf-coax-connectors-9419357.pdf?src-supplier=Avnet; ComponentLink11URL: https://datasheet.ciiva.com/9419/0734120110-rf-coax-connectors-9419357.pdf?src-supplier=Avnet; Contact Material: Bronze; Contact Plating: Gold; DatasheetVersion: 04/2016; Halogen Free: Low Halogen; Height: 1.25 mm; Lead Free: Lead Free; Length: 3 mm; Library Name: Altium Content Vault; Manufacturer Part Number: 73412-0110; Material: Bronze; Max Operating Temperature: 90 degC; Min Operating Temperature: -40 degC; Mount: Surface Mount; Mounting Technology: Surface Mount; Orientation: Straight; Package Quantity: 5000; PackageDescription: 4-Leads SMD, Body 2.6 x 2.6 mm; PackageReference: 73412-0110; PackageVersion: Rev. C14, 07/2015; Packaging: Tape and Reel; Pricing 1: 1=0.70000, 10=0.65600, 25=0.59040, 50=0.52480, 100=0.50300, 250=0.45928, 500=0.43740, 1000=0.36086, 2500=0.32805 (USD); Radiation Hardening: No; REACH SVHC: Unknown; RoHS: Yes; RoHSCompliant: true; Stock 1: 111881; Supplier 1: Digi-Key; Supplier Part Number 1: WM5587CT-ND; Termination: Solder; Voltage Rating (AC): 60 V; Width: 3 mm
